# Altium schematic sheet USB.SchDoc
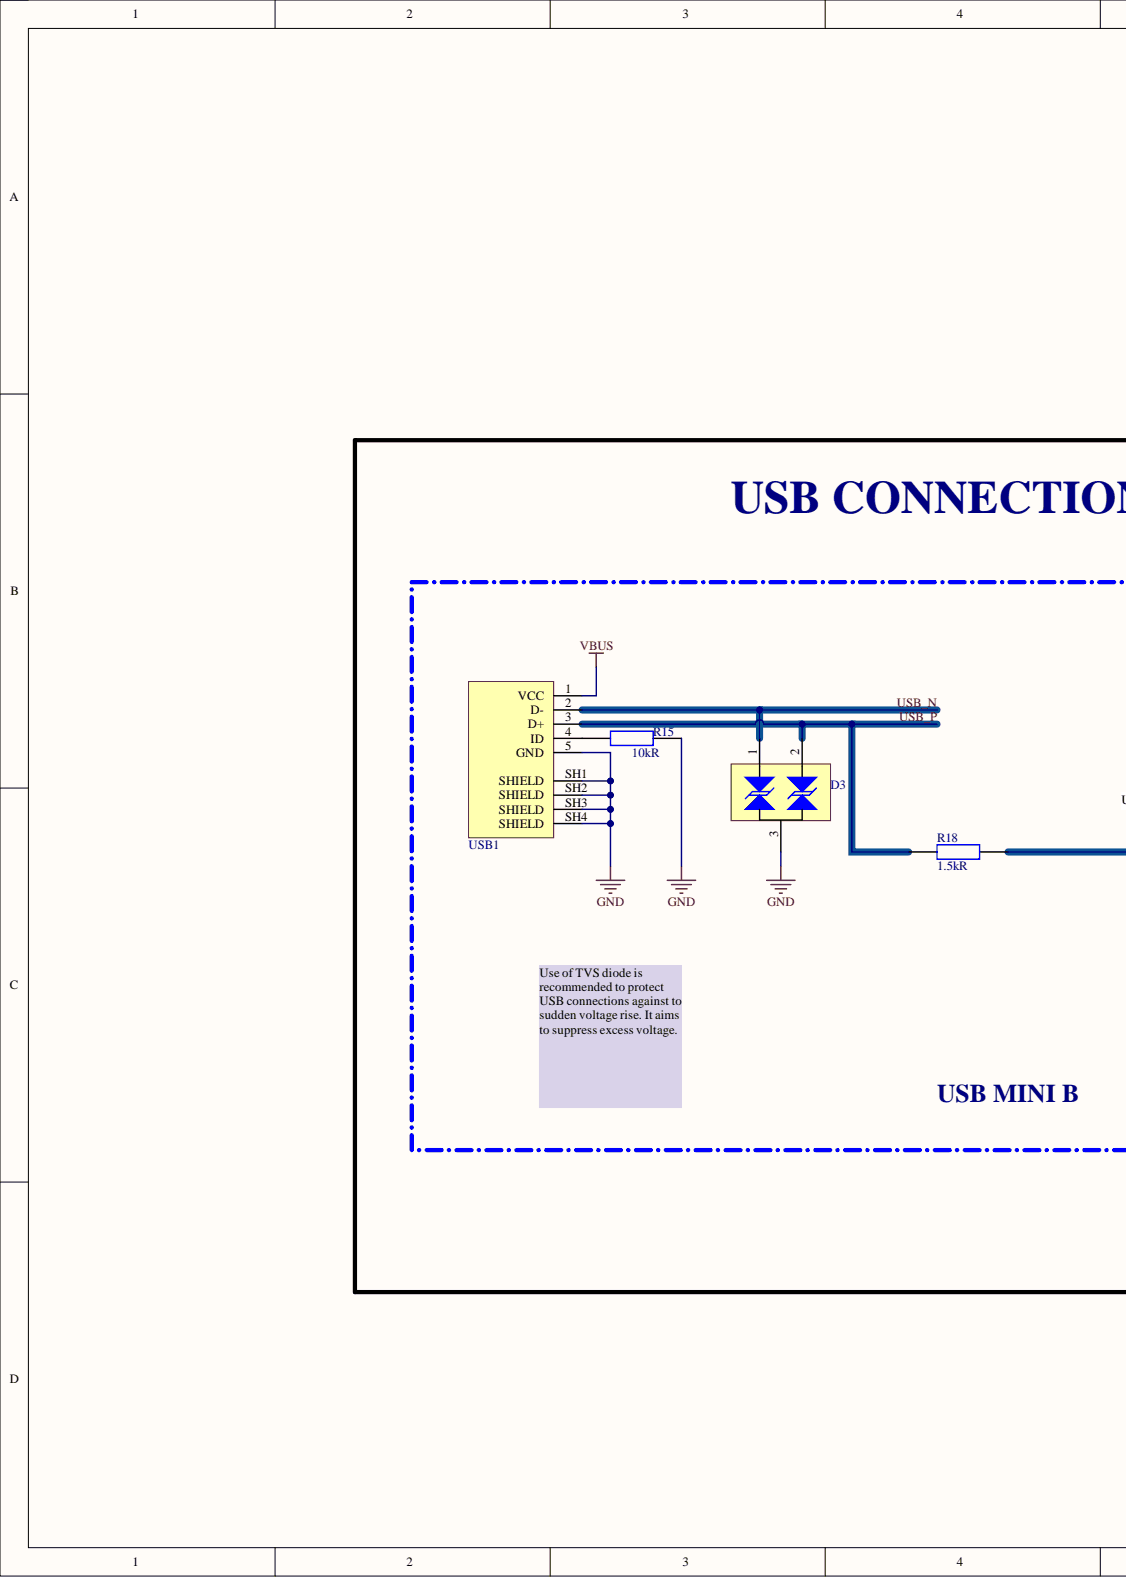
[diagram p0: USB.SchDoc, left]
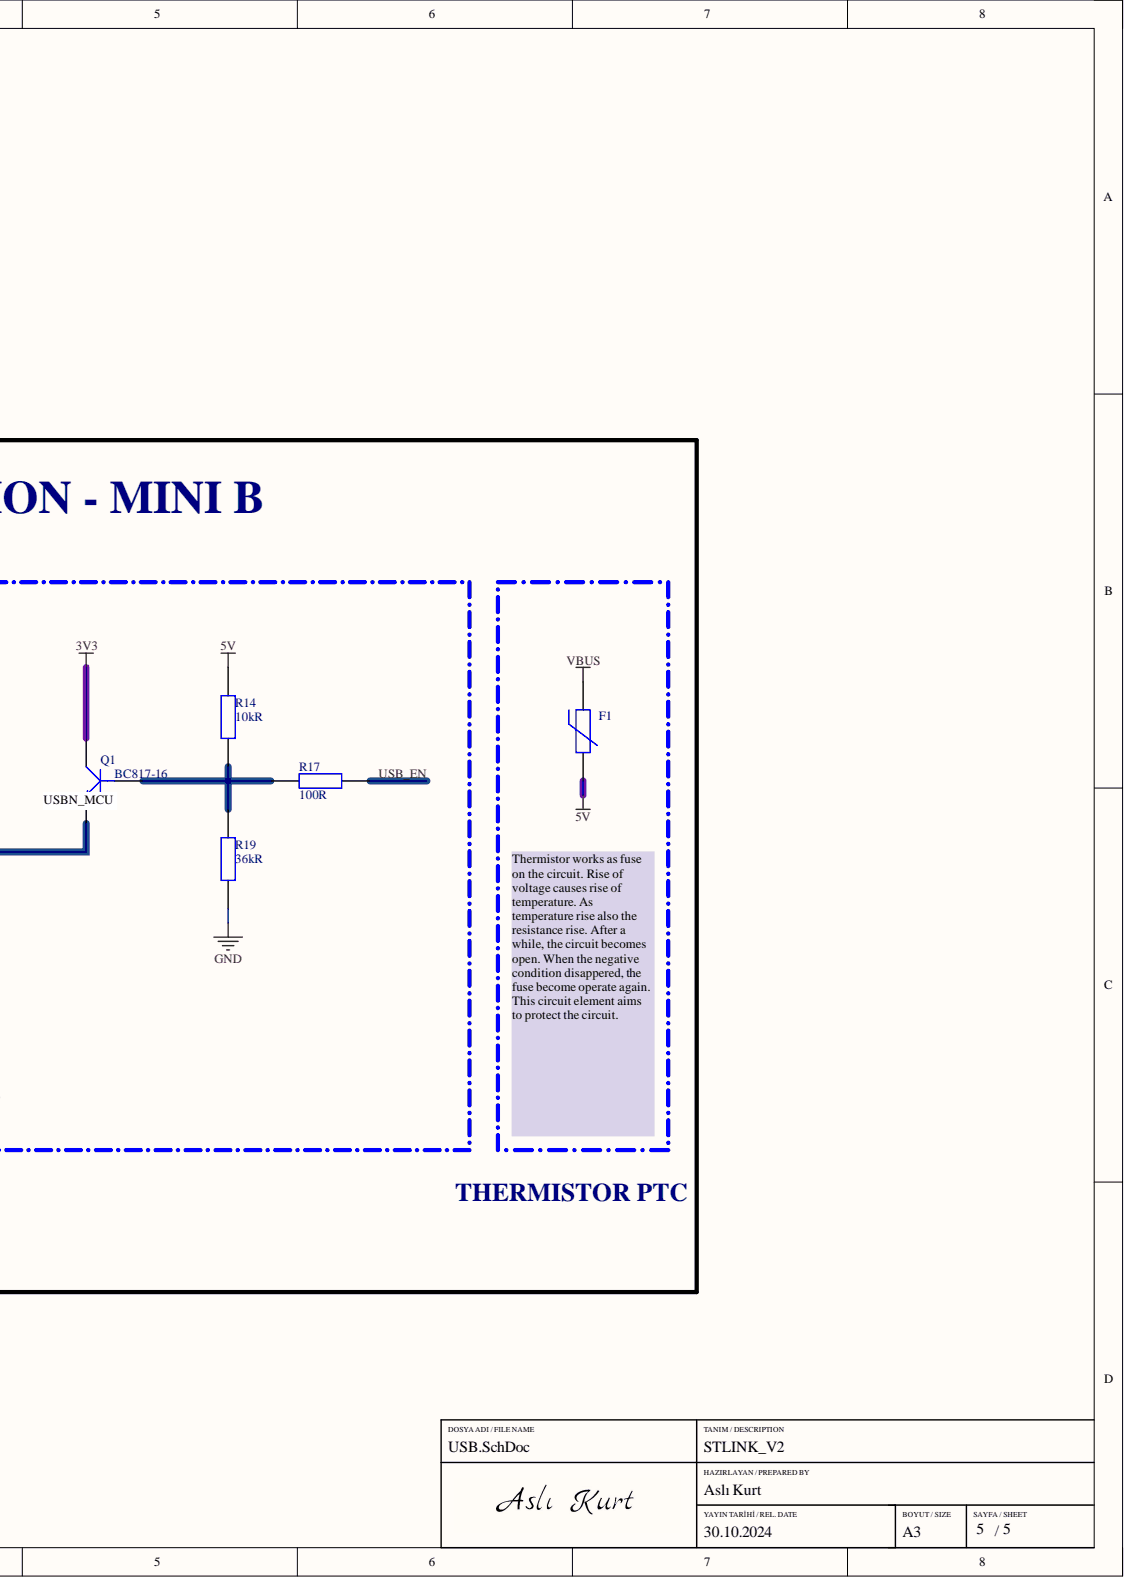
[diagram p1: USB.SchDoc, right]

## Parts
D3  SM712  [SOT-23]  (value not drawn)
F1  PRG21BC1R0MM1RA  [FUSE_0805]  (value not drawn)
Q1  BC817-16  [SOT23]
R14  Resistance: 10kR  [RES_0603]  (hidden comment: RC0603FR-0710KL)
R15  Resistance: 10kR  [RES_0603]  (hidden comment: RC0603FR-0710KL)
R17  Resistance: 100R  [RES_0603]  (hidden comment: RC0603JR-07100RL)
R18  Resistance: 1.5kR  [RES_0805]  (hidden comment: RC0805FR-071K5L)
R19  Resistance: 36kR  [RES_0805]  (hidden comment: RC0805FR-0736KL)
USB1  690-005-299-043  [MINI_USB_B]  (value not drawn)

## Nets
3V3: Q1.1
5V: F1.2 R14.2
GND: D3.3 R15.2 R19.1 USB1.5(GND) USB1.SH1(SHIELD) USB1.SH2(SHIELD) USB1.SH3(SHIELD) USB1.SH4(SHIELD)
VBUS: F1.1 USB1.1(VCC)
USB_EN: R17.2
USB_N: D3.1 USB1.2(D-)
USB_P: D3.2 R18.1 USB1.3(D+)
NetQ1_2: Q1.2 R14.1 R17.1 R19.2
NetQ1_3: Q1.3 R18.2
NetR15_1: R15.1 USB1.4(ID)

## Library data of the parts
D3 = SM712: 7V/12V Tvs, Package SOT-23, Tvs A; Manufacturer: Sangdest Microelectronics; DesignedBy: Aslı Kurt; Category: TVS Diodes; Component Kind: Standard; Library Name: MyLibrary.IntLib; Manufacturer Part Number: SM712; Pricing 1: 1=0,19, 10=0,132, 100=0,0887, 500=0,06778, 1000=0,06074 (USD); Pricing 2: 3000=0,05156, 6000=0,04684, 9000=0,04441, 15000=0,04165, 21000=0,04001, 30000=0,03841, 75000=0,03487, 150000=0,0339 (USD); RoHS: No; Stock 1: 85900; Stock 2: 84000; Supplier 1: Digikey; Supplier 2: Digikey; Supplier Part Number 1: 1655-SM712CT-ND; Supplier Part Number 2: 1655-SM712TR-ND
F1 = PRG21BC1R0MM1RA: Thermistor PTC 1 Ohm 20% 2-Pin 0805 Surface Mount Solder Pad Embossed T/R; Manufacturer: Murata; DesignedBy: Aslı Kurt; Voltage Rating: 12V; Category: Thermistors; Component Kind: Standard; ComponentLink1Description: https://datasheet.ciiva.com/30488/0900766b81259d6c-30488358.pdf?src-supplier=Rs; ComponentLink1URL: https://datasheet.ciiva.com/30488/0900766b81259d6c-30488358.pdf?src-supplier=Rs; ComponentLink2Description: https://datasheet.ciiva.com/327/ntc-ptcthermistorsforautomotive-327122.pdf?src-supplier=Digikey; ComponentLink2URL: https://datasheet.ciiva.com/327/ntc-ptcthermistorsforautomotive-327122.pdf?src-supplier=Digikey; ComponentLink3Description: https://datasheet.ciiva.com/29603/s0363e-29603286.pdf?src-supplier=Digikey; ComponentLink3URL: https://datasheet.ciiva.com/29603/s0363e-29603286.pdf?src-supplier=Digikey; ComponentLink4Description: https://datasheet.ciiva.com/29603/s0363e-29603287.pdf?src-supplier=Digikey; ComponentLink4URL: https://datasheet.ciiva.com/29603/s0363e-29603287.pdf?src-supplier=Digikey; ComponentLink5Description: https://datasheet.ciiva.com/29603/s0363e-29603289.pdf?src-supplier=Digikey; ComponentLink5URL: https://datasheet.ciiva.com/29603/s0363e-29603289.pdf?src-supplier=Digikey; ComponentLink6Description: https://datasheet.ciiva.com/3282/r90e-3282510.pdf?src-supplier=Avnet; ComponentLink6URL: https://datasheet.ciiva.com/3282/r90e-3282510.pdf?src-supplier=Avnet; Lead Free: 5697LeadFree; Library Name: MyLibrary.IntLib; Manufacturer Part Number: PRG21BC1R0MM1RA; Pricing 1: 1=0,62, 10=0,479, 50=0,479, 100=0,419, 1000=0,336, 10000=0,231 (USD); Pricing 2: 387=0,3643, 1000=0,3353, 4000=0,2764 (USD); Pricing 3: 1=0,73, 5=0,612, 10=0,568, 25=0,5156, 50=0,4788, 100=0,4449, 500=0,37522, 1000=0,3487 (USD); Pricing 4: 1=0,73, 5=0,612, 10=0,568, 25=0,5156, 50=0,4788, 100=0,4449, 500=0,37522, 1000=0,3487 (USD); Pricing 5: 4000=0,23392, 8000=0,23138 (USD); RoHS: Yes; Stock 1: 2720; Stock 2: 3860; Stock 3: 24892; Stock 4: 24892; Stock 5: 24000; Supplier 1: Mouser; Supplier 2: Arrow Electronics; Supplier 3: Digikey; Supplier 4: Digikey; Supplier 5: Digikey; Supplier Part Number 1: 81-PRG21BC1R0MM1RA; Supplier Part Number 2: PRG21BC1R0MM1RA; Supplier Part Number 3: 490-8511-1-ND; Supplier Part Number 4: 490-8511-6-ND; Supplier Part Number 5: 490-8511-2-ND
Q1 = BC817-16: ON Semi BC817-16LT1G NPN Bipolar Transistor; 0.5 A; 45 V; 3-Pin SOT-23; Manufacturer: ON Semiconductor; Collector Base Voltage (VCBO): 50V; Collector Emitter Breakdown Voltage: 45V; Collector Emitter Saturation Voltage: 700mV; Continuous Collector Current: 500mA; DesignedBy: Aslı Kurt; Emitter Base Voltage (VEBO): 5V; Gain Bandwidth Product: 100MHz; Max Power Dissipation: 300mW; Output Voltage: 15V; Case/Package: SOT-23-3; Category: BJTs; Collector-emitter Voltage-Max: 700mV; Component Kind: Standard; Current Rating: 500mA; Halogen Free: Halogen Free; Height: 0.94mm; Lead Free: Lead Free; Length: 2.9mm; Library Name: MyLibrary.IntLib; Manufacturer Part Number: BC817-16LT1G; Max Breakdown Voltage: 45V; Max Collector Current: 500mA; Max Operating Temperature: 150°C; Min Operating Temperature: -55°C; Number of Pins: 3; Package Quantity: 3000; Packaging: Tape and Reel; Polarity: NPN; Pricing 1: 3000=0,0209, 12000=0,02, 30000=0,0147, 45000=0,0192, 75000=0,0143, 120000=0,0186, 300000=0,0177 (USD); Pricing 2: 1=0,158, 25=0,097, 50=0,079, 100=0,06, 250=0,052 (USD); Pricing 3: 3000=0,0223, 9000=0,016, 99000=0,0133 (USD); Pricing 4: 1000=0,033, 2000=0,028 (GBP); Pricing 5: 200=0,013, 1000=0,033, 2000=0,028 (GBP); Pricing 6: 1=0,1, 10=0,066, 100=0,0444, 500=0,03362, 1000=0,02993, 3000=0,02508, 6000=0,02256, 9000=0,02126, 15000=0,01978, 21000=0,0189, 30000=0,01803, 75000=0,01612, 150000=0,01492, 300000=0,0139 (USD); Pricing 7: 1=0,1, 10=0,066, 100=0,0444, 500=0,03362, 1000=0,02993 (USD); RoHS: Yes; RoHS Compliant: Yes; Series: -; Stock 1: 63000; Stock 2: 3965; Stock 3: 75000; Stock 4: 73400; Stock 5: 2400; Stock 6: 15878; Stock 7: 16878; Supplier 1: Future Electronics; Supplier 2: Avnet; Supplier 3: Arrow Electronics; Supplier 4: Rs; Supplier 5: Rs; Supplier 6: Digikey; Supplier 7: Digikey; Supplier Part Number 1: 6937544; Supplier Part Number 2: 98H0503; Supplier Part Number 3: BC817-16LT1G; Supplier Part Number 4: 463616P; Supplier Part Number 5: 463616; Supplier Part Number 6: BC817-16LT1GOSCT-ND; Supplier Part Number 7: BC817-16LT1GOSDKR-ND; Transition Frequency: 100MHz; Voltage Rating (DC): 45V; Weight: 0.001058oz; Width: 1.3mm
R14 R15 = RES 10K OHM 0603: RES 10K %1 OHM 0603 SMD; Manufacturer: Yageo; DesignedBy: Aslı Kurt; Max Power Dissipation: 100mW; Resistance Tolerance: 1%; Temperature Coefficient: 100ppm/°C; Voltage Rating: 75V; Voltage Rating (DC): 50V; Case Code (Metric): 1608; Case Code (Imperial): 0603; Case/Package: 0603; Category: Chip SMD Resistors; Component Kind: Standard; ComponentLink1Description: https://datasheet.ciiva.com/7660/38590-7660789.pdf?src-supplier=Element14; ComponentLink1URL: https://datasheet.ciiva.com/7660/38590-7660789.pdf?src-supplier=Element14; ComponentLink2Description: https://datasheet.ciiva.com/15189/getdatasheetpartid-409289-15189591.pdf?src-supplier=Verical; ComponentLink2URL: https://datasheet.ciiva.com/15189/getdatasheetpartid-409289-15189591.pdf?src-supplier=Verical; ComponentLink3Description: https://datasheet.ciiva.com/46440/1868787-46440891.pdf?src-supplier=Element14; ComponentLink3URL: https://datasheet.ciiva.com/46440/1868787-46440891.pdf?src-supplier=Element14; ComponentLink4Description: https://datasheet.ciiva.com/7983/443971-7983596.pdf?src-supplier=Element14; ComponentLink4URL: https://datasheet.ciiva.com/7983/443971-7983596.pdf?src-supplier=Element14; ComponentLink5Description: https://datasheet.ciiva.com/7746/1738695-7746239.pdf?src-supplier=Element14; ComponentLink5URL: https://datasheet.ciiva.com/7746/1738695-7746239.pdf?src-supplier=Element14; ComponentLink6Description: https://datasheet.ciiva.com/22747/443971-22747519.pdf?src-supplier=Element14; ComponentLink6URL: https://datasheet.ciiva.com/22747/443971-22747519.pdf?src-supplier=Element14; Construction: Rectangular; Depth: 0.8mm; Features: Moisture Resistant; Height: 0.45mm; Lead Free: Lead Free; Lead Pitch: 0.3mm; Length: 1.6mm; Library Name: MyLibrary.IntLib; Manufacturer Part Number: RC0603FR-0710KL; Max Operating Temperature: 155°C; Military Standard: Not; Min Operating Temperature: -55°C; Number of Pins: 2; Number of Terminations: 2; Packaging: Tape and Reel; Power Rating: 100mW; Pricing 1: 1=0,10000, 10=0,02300, 100=0,00930, 1000=0,00417, 2500=0,00362 (USD); REACH SVHC: Unknown; Resistor Type: Thick Film; RoHS: Yes; RoHS Compliant: Yes; Series: RC; Size Code: 0603; Stock 1: 24140601; Supplier 1: Digi-Key; Supplier Part Number 1: 311-10.0KHRCT-ND; Termination: SMD/SMT; Tolerance: 1%; Weight: 7.1E-05oz; Width: 0.8mm
R17 = RES 100 OHM 0603: RES 100 %5 OHM 0603 SMD; Manufacturer: Yageo; DesignedBy: Aslı Kurt; Max Power Dissipation: 100mW; Resistance Tolerance: 5%; Temperature Coefficient: 100ppm/°C; Voltage Rating: 50V; Case Code (Metric): 1608; Case Code (Imperial): 0603; Case/Package: 0603; Category: Chip SMD Resistors; Component Kind: Standard; ComponentLink1Description: https://datasheet.ciiva.com/15189/getdatasheetpartid-325207-15189846.pdf?src-supplier=Verical; ComponentLink1URL: https://datasheet.ciiva.com/15189/getdatasheetpartid-325207-15189846.pdf?src-supplier=Verical; ComponentLink2Description: https://datasheet.ciiva.com/8883/pyu-rc0603-51-rohs-l-4-8883047.pdf?src-supplier=Avnet; ComponentLink2URL: https://datasheet.ciiva.com/8883/pyu-rc0603-51-rohs-l-4-8883047.pdf?src-supplier=Avnet; ComponentLink3Description: https://datasheet.ciiva.com/7746/443971-7746425.pdf?src-supplier=Element14; ComponentLink3URL: https://datasheet.ciiva.com/7746/443971-7746425.pdf?src-supplier=Element14; ComponentLink4Description: https://datasheet.ciiva.com/7746/443971-7746426.pdf?src-supplier=Element14; ComponentLink4URL: https://datasheet.ciiva.com/7746/443971-7746426.pdf?src-supplier=Element14; Construction: Rectangular; Contact Plating: Tin,Matte; Depth: 0.8mm; Features: Moisture Resistant; Lead Free: Lead Free; Length: 1.6mm; Library Name: MyLibrary.IntLib; Manufacturer Part Number: RC0603JR-07100RL; Max Operating Temperature: 155°C; Military Standard: Not; Min Operating Temperature: -55°C; Number of Pins: 2; Number of Terminations: 2; Packaging: Tape and Reel; Power Rating: 100mW; Pricing 1: 1=0,10000, 10=0,01900, 100=0,00770, 1000=0,00344, 2500=0,00298 (USD); Radiation Hardening: No; REACH SVHC: Unknown; Resistor Type: Thick Film; RoHS: Yes; RoHS Compliant: Yes; Series: RC; Size Code: 0603; Stock 1: 4334796; Supplier 1: Digi-Key; Supplier Part Number 1: 311-100GRCT-ND; Termination: SMD/SMT; Tolerance: 5%; Voltage Rating (DC): 50V; Width: 0.8mm
R18 = RES 1K5 OHM 0805: SMD Chip Resistor, 1.5 kOhm, ï¿½ 1%, 125 mW, 0805 [2012 Metric], Thick Film, General Purpose; Manufacturer: Yageo; DesignedBy: Aslı Kurt; Max Power Dissipation: 125mW; Temperature Coefficient: 100ppm/°C; Voltage Rating: 150V; Case Code (Metric): 2012; Case Code (Imperial): 0805; Case/Package: 0805; Category: Chip SMD Resistors; Component Kind: Standard; ComponentLink1Description: https://datasheet.ciiva.com/17423/rc0805-17423247.pdf?src-supplier=Future+Electronics; ComponentLink1URL: https://datasheet.ciiva.com/17423/rc0805-17423247.pdf?src-supplier=Future+Electronics; Features: Moisture Resistant; Height: 0.5mm; Lead Free: Lead Free; Length: 2mm; Library Name: MyLibrary.IntLib; Manufacturer Part Number: RC0805FR-071K5L; Max Operating Temperature: 155°C; Min Operating Temperature: -55°C; Number of Terminations: 2; Package Quantity: 5000; Packaging: Tape and Reel; Power Rating: 125mW; Pricing 1: 5000=0,0041, 25000=0,0039, 100000=0,0038, 250000=0,0037, 625000=0,0035 (USD); Pricing 2: 5000=0,00207 (USD); Pricing 3: 500=0,0091, 1000=0,0072, 2500=0,0071 (EUR); Pricing 4: 100=0,008, 1000=0,004, 2500=0,004, 5000=0,003, 10000=0,003 (GBP); Pricing 5: 1=0,1, 10=0,016, 50=0,016, 100=0,01, 1000=0,007, 10000=0,004 (USD); Pricing 6: 1=0,1, 10=0,016, 50=0,0132, 500=0,0102, 1000=0,00714 (USD); Reach SVHC Compliant: Unknown; Resistance Tolerance: 1%; Resistor Type: Thick Film; RoHS: Yes; RoHS Compliant: Yes; Series: RC; Size Code: 0805; Stock 1: 1590000; Stock 2: 10000; Stock 3: 120909; Stock 4: 13600; Stock 5: 365697; Stock 6: 528695; Supplier 1: Future Electronics; Supplier 2: Avnet; Supplier 3: Farnell; Supplier 4: Rs; Supplier 5: Mouser; Supplier 6: Digikey; Supplier Part Number 1: 4797627; Supplier Part Number 2: RC0805FR-071K5L; Supplier Part Number 3: 9237518RL; Supplier Part Number 4: 1995669; Supplier Part Number 5: 603-RC0805FR-071K5L; Supplier Part Number 6: 311-1.50KCRDKR-ND; Termination: SMD/SMT; Tolerance: 1%; Voltage Rating (AC): 150V; Width: 1.25mm
R19 = RES 36K OHM 0805: SMD Chip Resistor, 36 kOhm, ± 1%, 125 mW, 0805 [2012 Metric], Thick Film, General Purpose; Manufacturer: Yageo; DesignedBy: Aslı Kurt; Max Power Dissipation: 125mW; Temperature Coefficient: 100ppm/°C; Voltage Rating: 150V; Case Code (Metric): 2012; Case Code (Imperial): 0805; Case/Package: 0805; Category: Chip SMD Resistors; Component Kind: Standard; ComponentLink1Description: http://www.mouser.com/ds/2/447/Yageo_08112016_RC0805-968729.pdf?src-supplier=Mouser; ComponentLink1URL: http://www.mouser.com/ds/2/447/Yageo_08112016_RC0805-968729.pdf?src-supplier=Mouser; ComponentLink2Description: https://datasheet.octopart.com/RC0805FR-0736KL-Yageo-datasheet-164759695.pdf?src-supplier=Future+Electronics; ComponentLink2URL: https://datasheet.octopart.com/RC0805FR-0736KL-Yageo-datasheet-164759695.pdf?src-supplier=Future+Electronics; ComponentLink3Description: http://www.yageo.com/documents/recent/PYu-RC_Group_51_RoHS_L_9.pdf?src-supplier=Digikey; ComponentLink3URL: http://www.yageo.com/documents/recent/PYu-RC_Group_51_RoHS_L_9.pdf?src-supplier=Digikey; ComponentLink4Description: https://datasheet.octopart.com/RC0805FR-0736KL-Yageo-datasheet-118657.pdf?src-supplier=Digikey; ComponentLink4URL: https://datasheet.octopart.com/RC0805FR-0736KL-Yageo-datasheet-118657.pdf?src-supplier=Digikey; Features: Moisture Resistant; Lead Free: 5697LeadFree; Library Name: MyLibrary.IntLib; Manufacturer Part Number: RC0805FR-0736KL; Max Operating Temperature: 155°C; Min Operating Temperature: -55°C; Number of Terminations: 2; Packaging: Tape and Reel; Power Rating: 125mW; Pricing 1: 5000=0,0031, 25000=0,0029, 100000=0,0028, 250000=0,0027, 625000=0,0026 (USD); Pricing 2: 5000=0,0044, 10000=0,0038, 50000=0,0035, 250000=0,0032, 500000=0,0031 (USD); Pricing 3: 10=0,013, 100=0,008, 500=0,007, 1000=0,006, 2500=0,0055, 5000=0,005, 25000=0,004, 50000=0,0039 (EUR); Pricing 4: 1=0,1, 10=0,012, 50=0,012, 100=0,007, 1000=0,006, 10000=0,005 (USD); Pricing 5: 1=0,1, 10=0,016, 50=0,0132, 500=0,0102, 1000=0,00714 (USD); RoHS: Yes; RoHS Compliant: Yes; Series: RC; Stock 1: 215000; Stock 2: 20000; Stock 3: 8818; Stock 4: 76809; Stock 5: 212494; Supplier 1: Future Electronics; Supplier 2: Arrow Electronics; Supplier 3: Farnell; Supplier 4: Mouser; Supplier 5: Digikey; Supplier Part Number 1: 1143922; Supplier Part Number 2: RC0805FR-0736KL; Supplier Part Number 3: 3496035; Supplier Part Number 4: 603-RC0805FR-0736KL; Supplier Part Number 5: 311-36.0KCRCT-ND; Termination: SMD/SMT; Tolerance: 1%; Voltage Rating (DC): 150V
USB1 = 690-005-299-043: Conn Mini USB 2.0 Type B RCP 5 POS 0.8mm Solder RA SMD 5 Terminal 1 Port; Manufacturer: EDAC; DesignedBy: Aslı Kurt; Gender: Receptacle; Mating Cycles: 1500; Number of Contacts: 5; Number of Ports: 1; Shielding: Unshielded; Category: USB Connectors; Color: Black; Component Kind: Standard; ComponentLink1Description: https://datasheet.ciiva.com/30246/690-005-299-043-30246515.pdf?src-supplier=Onlinecomponents.com; ComponentLink1URL: https://datasheet.ciiva.com/30246/690-005-299-043-30246515.pdf?src-supplier=Onlinecomponents.com; ComponentLink2Description: https://datasheet.ciiva.com/29660/690-005-299-043-29660027.pdf?src-supplier=Digikey; ComponentLink2URL: https://datasheet.ciiva.com/29660/690-005-299-043-29660027.pdf?src-supplier=Digikey; ComponentLink3Description: https://datasheet.ciiva.com/29660/690-005-299-043-29660028.pdf?src-supplier=Digikey; ComponentLink3URL: https://datasheet.ciiva.com/29660/690-005-299-043-29660028.pdf?src-supplier=Digikey; ComponentLink4Description: https://datasheet.ciiva.com/29660/690-005-299-043-29660029.pdf?src-supplier=Digikey; ComponentLink4URL: https://datasheet.ciiva.com/29660/690-005-299-043-29660029.pdf?src-supplier=Digikey; Connector Type: USB; Contact Material: Bronze; Contact Plating: Gold; Flammability Rating: UL94 V-0; Housing Material: Thermoplastic; Lead Free: Lead Free; Library Name: MyLibrary.IntLib; Manufacturer Part Number: 690-005-299-043; Max Operating Temperature: 80°C; Min Operating Temperature: -30°C; Mount: Surface Mount,Horizontal; Orientation: Right Angle; Packaging: Cut Tape; Pitch: 0.8mm; Pricing 1: 1=0,71, 10=0,476, 50=0,476, 100=0,397, 1000=0,337, 10000=0,27 (USD); Pricing 2: 1=0,75, 10=0,573, 25=0,5148, 50=0,4754, 100=0,4392, 250=0,39636, 500=0,36736 (USD); Pricing 3: 1=0,75, 10=0,573, 25=0,5148, 50=0,4754, 100=0,4392, 250=0,39636, 500=0,36736 (USD); Pricing 4: 1000=0,34102, 2000=0,31713, 3000=0,3042, 5000=0,28894, 7000=0,27949, 10000=0,26996, 25000=0,25 (USD); RoHS: No; Series: 690; Stock 1: 13065; Stock 2: 62687; Stock 3: 62687; Stock 4: 62000; Supplier 1: Mouser; Supplier 2: Digikey; Supplier 3: Digikey; Supplier 4: Digikey; Supplier Part Number 1: 587-690-005-299-043; Supplier Part Number 2: 151-1206-1-ND; Supplier Part Number 3: 151-1206-6-ND; Supplier Part Number 4: 151-1206-2-ND; Termination: Solder; Insulation Color: Black
